# Altium schematic sheet ESPZ2Mv1.0_ESP.SchDoc
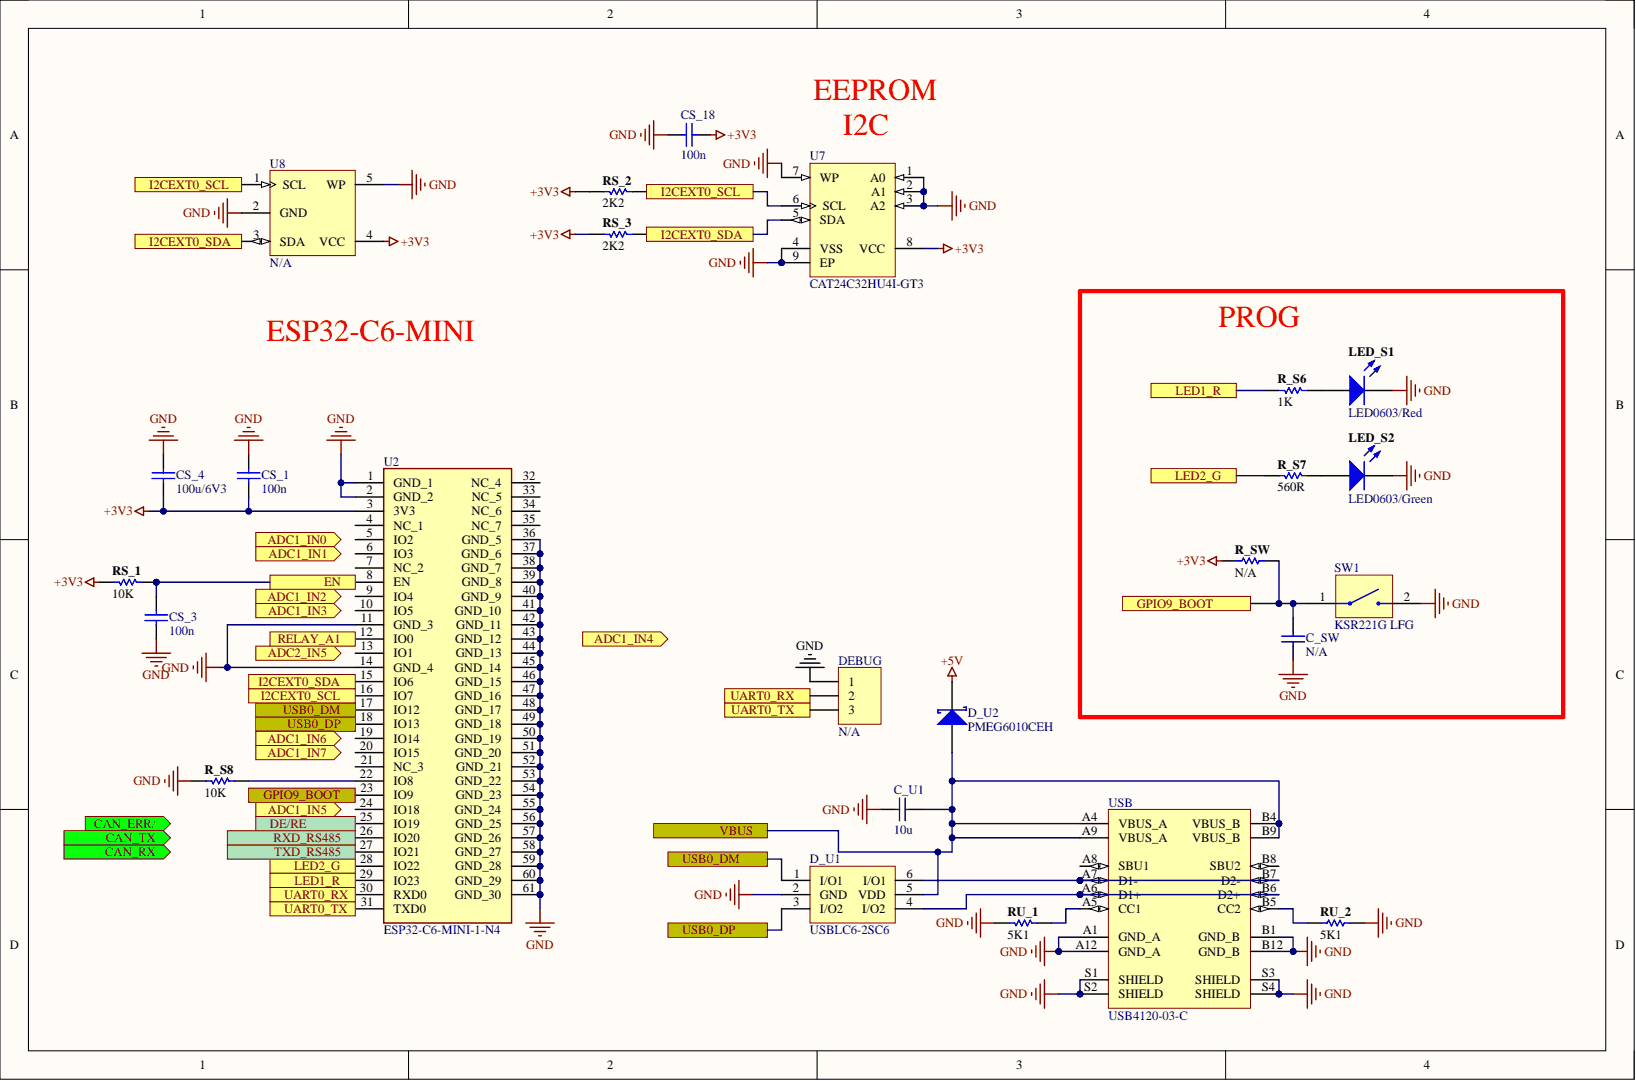
[diagram p0: ESPZ2Mv1.0_ESP.SchDoc]

## Parts
C_SW  N/A  [CAPC1608L]
C_U1  10u  [CAPC1608L]
CS_1  100n  [CAPC1608L]
CS_3  100n  [CAPC1608L]
CS_4  100u/6V3  [CAPC3216L]
CS_18  100n  [CAPC1608L]
D_U1  USBLC6-2SC6  [SOT23-6_N]
D_U2  PMEG6010CEH  [SOD123HE]
DEBUG  N/A  [HDR1X3N]
LED_S1  LED0603/Red  [LED0603_R]
LED_S2  LED0603/Green  [LED0603_G]
R_S6  1K  [RESC1608L]
R_S7  560R  [RESC1608L]
R_S8  10K  [RESC1608L]
R_SW  N/A  [RESC1608L]
RS_1  10K  [RESC1608L]
RS_2  2K2  [RESC1608L]
RS_3  2K2  [RESC1608L]
RU_1  5K1  [RESC1608L]
RU_2  5K1  [RESC1608L]
SW1  KSR221G LFG  [KSRxxxGLFG]
U2  ESP32-C6-MINI-1-N4  [ESP32C6MINI1N4]
U7  CAT24C32HU4I-GT3  [UDFN-8]
U8  N/A  [SOT23-5_N]
USB  USB4120-03-C  [USB-C-V_GCT]

## Ports
ADC1_IN0, ADC1_IN1, ADC1_IN2, ADC1_IN3, ADC1_IN4, ADC1_IN5, ADC1_IN6, ADC1_IN7, ADC2_IN5, CAN_ERR/, CAN_RX, CAN_TX, DE/RE, EN, GPIO9_BOOT, I2CEXT0_SCL, I2CEXT0_SDA, LED1_R, LED2_G, RELAY_A1, RXD_RS485, TXD_RS485, UART0_RX, UART0_TX, USB0_DM, USB0_DP, VBUS

## Nets
+3V3: CS_1.2 CS_4.2 CS_18.2 R_SW.2 RS_1.1 RS_2.1 RS_3.1 U2.3(3V3) U7.8(VCC) U8.4(VCC)
+5V: D_U2.2
GND: C_SW.1 C_U1.1 CS_1.1 CS_3.1 CS_4.1 CS_18.1 D_U1.2(GND) DEBUG.1 LED_S1.2 LED_S2.2 R_S8.2 RU_1.1 RU_2.1 SW1.2 U2.1(GND_1) U2.2(GND_2) U2.11(GND_3) U2.14(GND_4) U2.36(GND_5) U2.37(GND_6) U2.38(GND_7) U2.39(GND_8) U2.40(GND_9) U2.41(GND_10) U2.42(GND_11) U2.43(GND_12) U2.44(GND_13) U2.45(GND_14) U2.46(GND_15) U2.47(GND_16) U2.48(GND_17) U2.49(GND_18) U2.50(GND_19) U2.51(GND_20) U2.52(GND_21) U2.53(GND_22) U2.54(GND_23) U2.55(GND_24) U2.56(GND_25) U2.57(GND_26) U2.58(GND_27) U2.59(GND_28) U2.60(GND_29) U2.61(GND_30) U7.1(A0) U7.2(A1) U7.3(A2) U7.4(VSS) U7.7(WP) U7.9(EP) U8.2(GND) U8.5(WP) USB.A1(GND_A) USB.A12(GND_A) USB.B1(GND_B) USB.B12(GND_B) USB.S1(SHIELD) USB.S2(SHIELD) USB.S3(SHIELD) USB.S4(SHIELD)
DE/RE: U2.25(IO19) ; port DE/RE
EN: CS_3.2 RS_1.2 U2.8(EN) ; port EN
GPIO9_BOOT: C_SW.2 R_SW.1 SW1.1 U2.23(IO9) ; port GPIO9_BOOT
I2CEXT0_SCL: RS_2.2 U2.16(IO7) U7.6(SCL) U8.1(SCL) ; port I2CEXT0_SCL
I2CEXT0_SDA: RS_3.2 U2.15(IO6) U7.5(SDA) U8.3(SDA) ; port I2CEXT0_SDA
LED1_R: R_S6.2 U2.29(IO23) ; port LED1_R
LED2_G: R_S7.2 U2.28(IO22) ; port LED2_G
RELAY_A1: U2.12(IO0) ; port RELAY_A1
RXD_RS485: U2.26(IO20) ; port RXD_RS485
TXD_RS485: U2.27(IO21) ; port TXD_RS485
UART0_RX: DEBUG.2 U2.30(RXD0) ; port UART0_RX
UART0_TX: DEBUG.3 U2.31(TXD0) ; port UART0_TX
USB0_DM: D_U1.1(I/O1) U2.17(IO12) ; port USB0_DM
USB0_DP: D_U1.3(I/O2) U2.18(IO13) ; port USB0_DP
VBUS: C_U1.2 D_U1.5(VDD) D_U2.1 USB.A4(VBUS_A) USB.A9(VBUS_A) USB.B4(VBUS_B) USB.B9(VBUS_B) ; port VBUS
NetD_U1_4: D_U1.4(I/O2) USB.A6(D1+) USB.B6(D2+)
NetD_U1_6: D_U1.6(I/O1) USB.A7(D1-) USB.B7(D2-)
NetLED_S1_1: LED_S1.1 R_S6.1
NetLED_S2_1: LED_S2.1 R_S7.1
NetR_S8_1: R_S8.1 U2.22(IO8)
NetRU_1_2: RU_1.2 USB.A5(CC1)
NetRU_2_2: RU_2.2 USB.B5(CC2)
ADC1_IN0: ; port ADC1_IN0
ADC1_IN1: ; port ADC1_IN1
ADC1_IN2: ; port ADC1_IN2
ADC1_IN3: ; port ADC1_IN3
ADC1_IN4: ; port ADC1_IN4
ADC1_IN5: ; port ADC1_IN5
ADC1_IN6: ; port ADC1_IN6
ADC1_IN7: ; port ADC1_IN7
ADC2_IN5: ; port ADC2_IN5
CAN_ERR/: ; port CAN_ERR/
CAN_RX: ; port CAN_RX
CAN_TX: ; port CAN_TX

Not connected: U2 4(NC_1) 5(IO2) 6(IO3) 7(NC_2) 9(IO4) 10(IO5) 13(IO1) 19(IO14) 20(IO15) 21(NC_3) 24(IO18) 32(NC_4) 33(NC_5) 34(NC_6) 35(NC_7); USB A8(SBU1) B8(SBU2)

## Library data of the parts
C_SW = Cap Semi: Capacitor Chip, 0603; Value: N/A-100n; Category: Capacitor; Component Kind: Standard; Library Name: Lib-USER.IntLib; Mounting Technology: Surface Mount; PackageReference: 0603; Price: 0,004
C_U1 = Cap Semi: Capacitor Chip, 0603; Tolerance: X5R-20%; Category: Capacitor; Component Kind: Standard; Library Name: Lib-USER.IntLib; Mounting Technology: Surface Mount; PackageReference: 0603; Price: 0,03; Value: 10u
CS_1 CS_3 CS_4 CS_18 = Cap Semi: Capacitor Chip, 0603; Category: Capacitor; Component Kind: Standard; Library Name: Lib-USER.IntLib; Mounting Technology: Surface Mount; PackageReference: 0603
  CS_1 CS_3 CS_18: Price: 0,004; Value: 100n
  CS_4: Price: 0,03; Value: 100u/6V3
D_U1 = ESD_USB: Diode Array, TVS for ESD; Category: ESD Protection; Component Kind: Standard; Library Name: Lib-USER.IntLib; Mounting Technology: SurfaceMount; PackageReference: SOT23-6; PartNumber: USBLC6-2SC6; Price: 0,2; Value: USBLC6-2SC6
D_U2 = D Schottky: Diode Schottky, SOD123F; Category: Diode Schottky; Component Kind: Standard; Library Name: Lib-USER.IntLib; Mounting Technology: Surface Mount; PackageReference: SOD123F; Price: 0,08; Value: PMEG6010CEH
DEBUG = Header 3X1: Header, 3-Pin; Value: N/A-HDR1X3, 2.54mnm; Category: Header; Component Kind: Standard; Library Name: Lib-Connectors.IntLib; Mounting Technology: Thru-Hole; PackageReference: HDR1X3; Price: 0,03
LED_S1 = LED_SMD: LED0603, Red; Category: LED; Component Kind: Standard; Library Name: Lib-USER.IntLib; Mounting Technology: Surface Mount; PackageReference: LED0603; Price: 0,023; Value: LED0603/Red
LED_S2 = LED_SMD: LED0603, Green; Category: LED; Component Kind: Standard; Library Name: Lib-USER.IntLib; Mounting Technology: Surface Mount; PackageReference: LED0603; Price: 0,023; Value: LED0603/Green
R_S6-R_S8 R_SW RS_1-RS_3 RU_1 RU_2 = Res Semi: Resistor, 1% 0603 (1608); Category: Resistor; Component Kind: Standard; Library Name: Lib-USER.IntLib; Mounting Technology: Surface Mount; PackageReference: 0603; Price: 0,002; Tolerance: 1%
  R_S6: Value: 1K
  R_S7: Value: 560R
  R_S8 RS_1: Value: 10K
  R_SW: Value: N/A
  RS_2 RS_3: Value: 2K2
  RU_1 RU_2: Value: 5K1
SW1 = KSRxxx: Switch Micro SPST-NO, SMD; PartNumber: WE-434121025816; PartNumber_1: KSR222G LFS; Category: Microswitch; Component Kind: Standard; Library Name: Lib-USER.IntLib; Mounting Technology: Surface Mount; PackageReference: KSRxxxGLFG; Price: 0,575; Value: KSR221G LFG
U2 = ESP32-C6-MINI-1-N4: Integrated Circuit; PartNumber: ESP32-C6-MINI-1-H4; Component Kind: Standard; Mounting Technology: Surface Mount; PackageReference: ESP32-C6-MINI; Price: 2,33; Value: ESP32-C6-MINI-1-N4
U7 = 24FC04HT: IC, EEPROM, I2C; Category: EEPROM; Component Kind: Standard; Library Name: Lib-USER.IntLib; Mounting Technology: Surface Mount; PackageReference: UDFN8; Price: 0,356; Value: CAT24C32HU4I-GT3
U8 = 24AA02UID: IC, EEPROM, I2C; PartNumber: min. 24AA02T-I/OT; PartNumber_1: max. 24AA64T-I/OT; Value: N/A-24AA64T-I/OT; Category: EEPROM with Serial Number; Component Kind: Standard; Library Name: Lib-USER.SCHLIB; Mounting Technology: Surface Mount; PackageReference: SOT-23-5; Price: 0,172
USB = USBC-V_GCT: Connector, USBC 2.0 Vertical, 16 Contacts; Category: Connector; Component Kind: Standard; Library Name: Lib-Connectors.IntLib; Mounting Technology: Surface Mount; PackageReference: USBC-V_GCT; PartNumber: USB4120; Price: 0,785; Value: USB4120-03-C
